# Altium schematic sheet Calimotion 7.SchDoc("Calimotion 7")
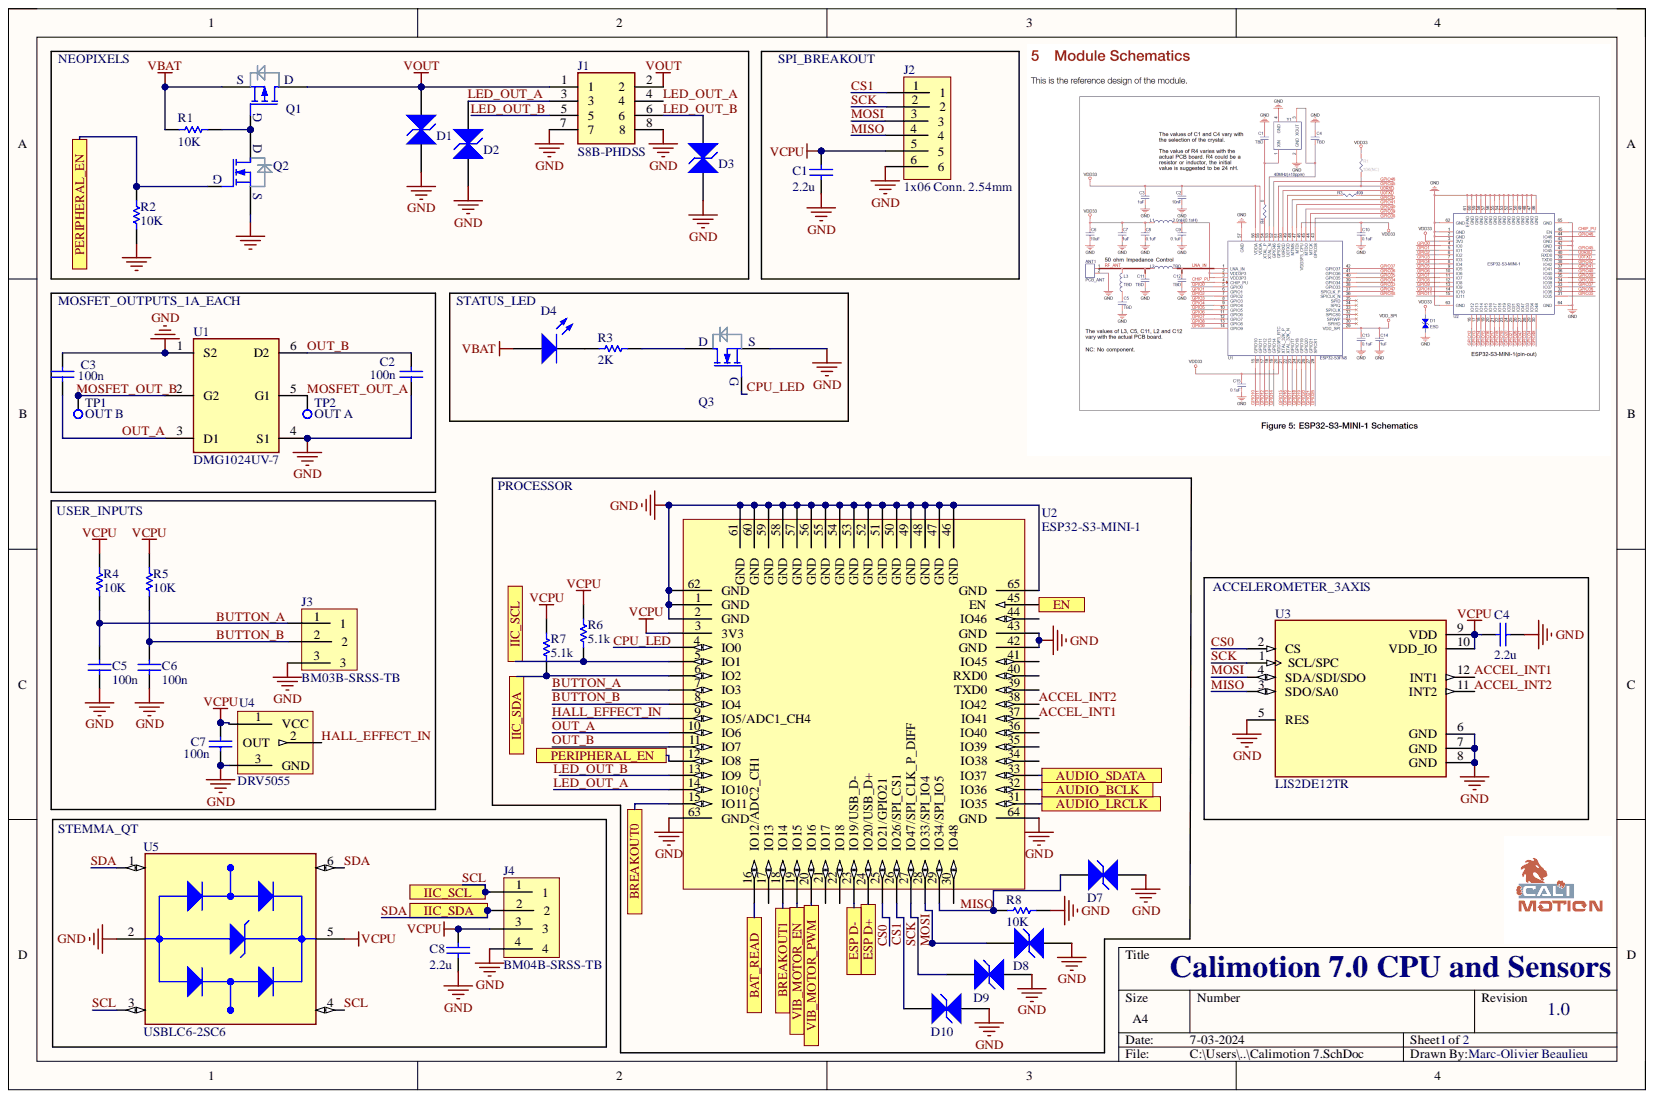
[diagram p0: Calimotion 7.SchDoc]

## Parts
C1  value 2.2u  [CAP 0402_1005]  (hidden comment: Capacitor)
C2  value 100n  [CAP 0402_1005]  (hidden comment: Capacitor)
C3  value 100n  [CAP 0402_1005]  (hidden comment: Capacitor)
C4  value 2.2u  [CAP 0402_1005]  (hidden comment: Capacitor)
C5  value 100n  [CAP 0402_1005]  (hidden comment: Capacitor)
C6  value 100n  [CAP 0402_1005]  (hidden comment: Capacitor)
C7  value 100n  [CAP 0402_1005]  (hidden comment: Capacitor)
C8  value 2.2u  [CAP 0402_1005]  (hidden comment: Capacitor)
D1  TVS  [SOD523_DIODE]  (value not drawn)
D2  TVS  [SOD523_DIODE]  (value not drawn)
D3  TVS  [SOD523_DIODE]  (value not drawn)
D4  Light-Emitting Diode  [LEDSC160X80X70L45N]  (value not drawn)
D7  TVS  [SOD523_DIODE]  (value not drawn)
D8  TVS  [SOD523_DIODE]  (value not drawn)
D9  TVS  [SOD523_DIODE]  (value not drawn)
D10  TVS  [SOD523_DIODE]  (value not drawn)
J1  S8B-PHDSS  [JST_S8B-PHDSS]
J2  1x06 Conn. 2.54mm  [1x06 Conn. 2.54mm]
J3  BM03B-SRSS-TB  [BM03B-SRSS-TB]
J4  BM04B-SRSS-TB  [BM04B-SRSS-TB]
Q1  MOSFET-P  [8-DFN-EP (3.3x3.3)]  (value not drawn)
Q2  MOSFET-N  [SC-75]  (value not drawn)
Q3  MOSFET-N  [SOT-23-3-MOSFET]  (value not drawn)
R1  value 10K  [RES 0402_1005 RF]  (hidden comment: Resistor)
R2  value 10K  [RES 0402_1005 RF]  (hidden comment: Resistor)
R3  value 2K  [RES 0402_1005 RF]  (hidden comment: Resistor)
R4  value 10K  [RES 0402_1005 RF]  (hidden comment: Resistor)
R5  value 10K  [RES 0402_1005 RF]  (hidden comment: Resistor)
R6  value 5.1k  [RES 0402_1005 RF]  (hidden comment: Resistor)
R7  value 5.1k  [RES 0402_1005 RF]  (hidden comment: Resistor)
R8  value 10K  [RES 0402_1005 RF]  (hidden comment: Resistor)
TP1  OUT B  [THT-Test_Point]
TP2  OUT A  [THT-Test_Point]
U1  DMG1024UV-7  [74LVC2G17GW,125]
U2  ESP32-S3-MINI-1  [ESP32-S3-MINI-1]
U3  LIS2DE12TR  [LIS2DE12TR_200X200X100-12N]
U4  DRV5055  [SOT23-3]
U5  USBLC6-2SC6  [SOT95P280X145-6N]

## Ports
AUDIO_BCLK, AUDIO_LRCLK, AUDIO_SDATA, BAT_READ, BREAKOUT0, BREAKOUT1, EN, ESP D+, ESP D-, IIC_SCL, IIC_SDA, PERIPHERAL_EN, VIB_MOTOR_EN, VIB_MOTOR_PWM

## Nets
GND: C1.1 C2.1 C3.2 C4.1 C5.2 C6.2 C7.1 C8.1 D1.A D2.A D3.A D7.A D8.A D9.A D10.A J1.7 J1.8 J2.6 J3.3 J4.4 Q2.S Q3.S R2.2 R8.1 U1.1(S2) U1.4(S1) U2.1(GND) U2.2(GND) U2.42(GND) U2.43(GND) U2.46(GND) U2.47(GND) U2.48(GND) U2.49(GND) U2.50(GND) U2.51(GND) U2.52(GND) U2.53(GND) U2.54(GND) U2.55(GND) U2.56(GND) U2.57(GND) U2.58(GND) U2.59(GND) U2.60(GND) U2.61(GND) U2.62(GND) U2.63(GND) U2.64(GND) U2.65(GND) U3.5(RES) U3.6(GND) U3.7(GND) U3.8(GND) U4.3 U5.2
VBAT: D4.1 Q1.S R1.2
VCPU: C1.2 C4.2 C7.2 C8.2 J2.5 J4.3 R4.1 R5.1 R6.2 R7.2 U2.3(3V3) U3.9(VDD) U3.10(VDD_IO) U4.1 U5.5
VOUT: D1.K J1.1 J1.2 Q1.D
ACCEL_INT1: U2.37(IO41) U3.12(INT1)
ACCEL_INT2: U2.38(IO42) U3.11(INT2)
AUDIO_BCLK: U2.32(IO36) ; port AUDIO_BCLK
AUDIO_LRCLK: U2.31(IO35) ; port AUDIO_LRCLK
AUDIO_SDATA: U2.33(IO37) ; port AUDIO_SDATA
BAT_READ: U2.16(IO12/ADC2_CH1) ; port BAT_READ
BREAKOUT0: U2.15(IO11) ; port BREAKOUT0
BREAKOUT1: U2.18(IO14) ; port BREAKOUT1
BUTTON_A: C5.1 J3.1 R4.2 U2.7(IO3)
BUTTON_B: C6.1 J3.2 R5.2 U2.8(IO4)
CPU_LED: Q3.G U2.4(IO0)
CS0: U2.25(IO21/GPIO21) U3.2(CS)
CS1: D10.K J2.1 U2.26(IO26/SPI_CS1)
EN: U2.45(EN) ; port EN
HALL_EFFECT_IN: U2.9(IO5/ADC1_CH4) U4.2
LED_OUT_A: D2.K J1.3 J1.4 U2.14(IO10)
LED_OUT_B: D3.K J1.5 J1.6
MISO: D7.K J2.4 R8.2 U2.29(IO34/SPI_IO5) U3.3(SDO/SA0)
MOSFET_OUT_A: TP2.1 U1.5(G1)
MOSFET_OUT_B: TP1.1 U1.2(G2)
MOSI: D8.K J2.3 U2.28(IO33/SPI_IO4) U3.4(SDA/SDI/SDO)
OUT_A: C3.1 U1.3(D1) U2.10(IO6)
OUT_B: C2.2 U1.6(D2) U2.11(IO7)
PERIPHERAL_EN: Q2.G R2.1 U2.12(IO8) ; port PERIPHERAL_EN
SCK: D9.K J2.2 U2.27(IO47/SPI_CLK_P_DIFF) U3.1(SCL/SPC)
SCL: J4.1 R6.1 U2.5(IO1) U5.3 U5.4 ; port IIC_SCL
SDA: J4.2 R7.1 U2.6(IO2) U5.1 U5.6 ; port IIC_SDA
VIB_MOTOR_EN: U2.19(IO15) ; port VIB_MOTOR_EN
VIB_MOTOR_PWM: U2.20(IO16) ; port VIB_MOTOR_PWM
NetD4_2: D4.2 R3.2
NetQ1_G: Q1.G Q2.D R1.1
NetQ3_D: Q3.D R3.1
NetU2_23: U2.23(IO19/USB_D-)
NetU2_24: U2.24(IO20/USB_D+)

Not connected: U2 13(IO9) 17(IO13) 21(IO17) 22(IO18) 30(IO48) 34(IO38) 35(IO39) 36(IO40) 39(TXD0) 40(RXD0) 41(IO45) 44(IO46)

## Library data of the parts
C1-C8 = Capacitor: SimulationGenericComponent: True; Component Kind: Standard; Description: Capacitor; Library Name: Simulation Generic Components.IntLib; Simulation: C_Capacitor_Default
D1-D3 D7-D10 = DIO_1027: TVS 5.8V 50W SOD523; Manufacturer: On Semiconductor; Part_number: ESD5B5.0ST1G; Bin: 2161 -- CT 198; P_Id: 27; Prod_Id: 1045; Component Kind: Standard; Curr: 50W; FB_PCB_Number: DIO_1027; Library Name: ALTIUM_Diode.IntLib; Price: 0.04790; Quantity: 0; Voltage: 5.8V
D4 = LED: AF: 1; BV: 0; BV_MAX: 1e99; EG: 1.11; FC: 0.5; IBV: 0.001; IS: 1e-14; MJSW: 0.33; NTUN: 30; SimulationGenericComponent: True; TNOM: 27; XTI: 3; CJO: 0; CJP: 0; Component Kind: Standard; CTA: 0; CTP: 0; Description: Light-Emitting Diode; FCS: 0.5; FV_MAX: 1e99; IKF: 0; IKR: 0; ISR: 1e-14; JSW: 0; JTUN: 0; JTUNSW: 0; KEG: 1; KF: 0; LEVEL: 1; Library Name: Simulation Generic Components.IntLib; N: 1; NBV: 1; NR: 1; NS: 1; PHP: 1; RS: 0; Simulation: diode; TCV: 0; TLEV: 0; TLEVC: 0; TM1: 0; TM2: 0; TPB: 0; TPHP: 0; TRS: 0; TRS2: 0; TT: 0; TTT1: 0; TTT2: 0; VJ: 1; XTITUN: 3
J1 = S8B-PHDSS: Connector Header Through Hole, Right Angle 8 position 0.079 _2.00mm_; MF: JST Sales; Availability: Unavailable; Component Kind: Standard; MP: S8B-PHDSS; Package: None; Price: None; SnapEDA_Link: https://www.snapeda.com/parts/S8B-PHDSS/JST%20Sales%20America%20Inc./view-part/761599/?ref=snap; Library Name: Importred_Components.IntLib
J3 = BM03B-SRSS-TB: Connector Header Surface Mount 3 position 0.039" (1.00mm); Component Kind: Standard; Library Name: Importred_Components.IntLib
J4 = BM04B-SRSS-TB: Connector Header Surface Mount 4 position 0.039" (1.00mm); Component Kind: Standard; Library Name: Importred_Components.IntLib
Q1 = TRANS_1055: MOSFET P-CH 20V 28A 8DFN; Manufacturer: Alpha & Omega Semiconductor Inc.; Part_number: AON7423; Component Kind: Standard; Curr: 28A; Library Name: ALTIUM_Transistor.IntLib; Price: 0.45; Voltage: 20V
Q2 = TRANS_1070: 20 V, 238 mA, Single, N−Channel, Gate ESD Protection, SC−75; Manufacturer: OnSemi; Part_number: NTA4001NT1G; Curr: 238mA; Pwr: 300mW; Voltage: 20V; Component Kind: Standard; Library Name: ALTIUM_Transistor.IntLib; Price: .01
Q3 = TRANS_1057: MOSFET N-CH 20V 915MA SOT-416; Manufacturer: ON Semiconductor; Part_number: NTA4153NT1G; Pwr: 300mW; Component Kind: Standard; Curr: 915mA; FB_PCB_Number: TRANS_1057; Library Name: ALTIUM_Transistor.IntLib; Price: NA; Quantity: 0; Voltage: 20V
R1-R8 = Resistor: SimulationGenericComponent: True; TC1: 0; Component Kind: Standard; Description: Resistor; Library Name: Simulation Generic Components.IntLib; Simulation: R_Resistor_Default; TC2: 0
TP1 TP2 = Test Point: Component Kind: Standard; Library Name: Celestial Library.IntLib
U1 = DMG1024UV-7: Mosfet Array 2 N-Channel _Dual_ 20V 1.38A 530mW Surface Mount SOT-563; MF: Diodes Inc.; Availability: Unavailable; Component Kind: Standard; MP: DMG1024UV-7; Package: SOT-563 Diodes Inc.; Price: None; SnapEDA_Link: https://www.snapeda.com/parts/DMG1024UV-7/Diodes%20Inc./view-part/391731/?ref=snap; Library Name: Importred_Components.IntLib
U2 = ESP32-S3-MINI-1: Bluetooth, WiFi 802.11b/g/n, Bluetooth v5.0 Transceiver Module 2.4GHz PCB Trace Surface Mount; Component Kind: Standard; Library Name: ESP32_library.IntLib
U3 = LIS2DE12TR: Accelerometer X, Y, Z Axis Â±2g, 4g, 8g, 16g 0.5Hz ~ 2.69kHz 12-LGA _2x2_; MF: STMicroelectronics; Availability: Unavailable; Component Kind: Standard; MP: LIS2DE12TR; Package: LGA-12 STMicroelectronics; Price: None; SnapEDA_Link: https://www.snapeda.com/parts/LIS2DE12TR/STMicroelectronics/view-part/470072/?ref=snap; Library Name: Importred_Components.IntLib
U4 = DRV5055: Hall Effect Sensor Single Axis SOT-23-3; Component Kind: Standard; Library Name: Importred_Components.IntLib
U5 = USBLC6-2SC6: 17V Clamp 5A (8/20Âµs) Ipp Tvs Diode Surface Mount SOT-23-6; MF: STMicroelectronics; Availability: In Stock; Check_prices: https://www.snapeda.com/parts/USBLC6-2SC6/STMicroelectronics/view-part/?ref=eda; Component Kind: Standard; MP: USBLC6-2SC6; Package: SOT-23-6 STMicroelectronics; Price: None; Purchase-URL: https://pricing.snapeda.com/search/part/USBLC6-2SC6/?ref=eda; SnapEDA_Link: https://www.snapeda.com/parts/USBLC6-2SC6/STMicroelectronics/view-part/?ref=snap; Library Name: Importred_Components.IntLib
J2 = 1x06 Conn. 2.54mm: Component Kind: Standard; Library Name: Importred_Components.IntLib
